# Altium schematic sheet Max +2A Issue 1a.SchDoc
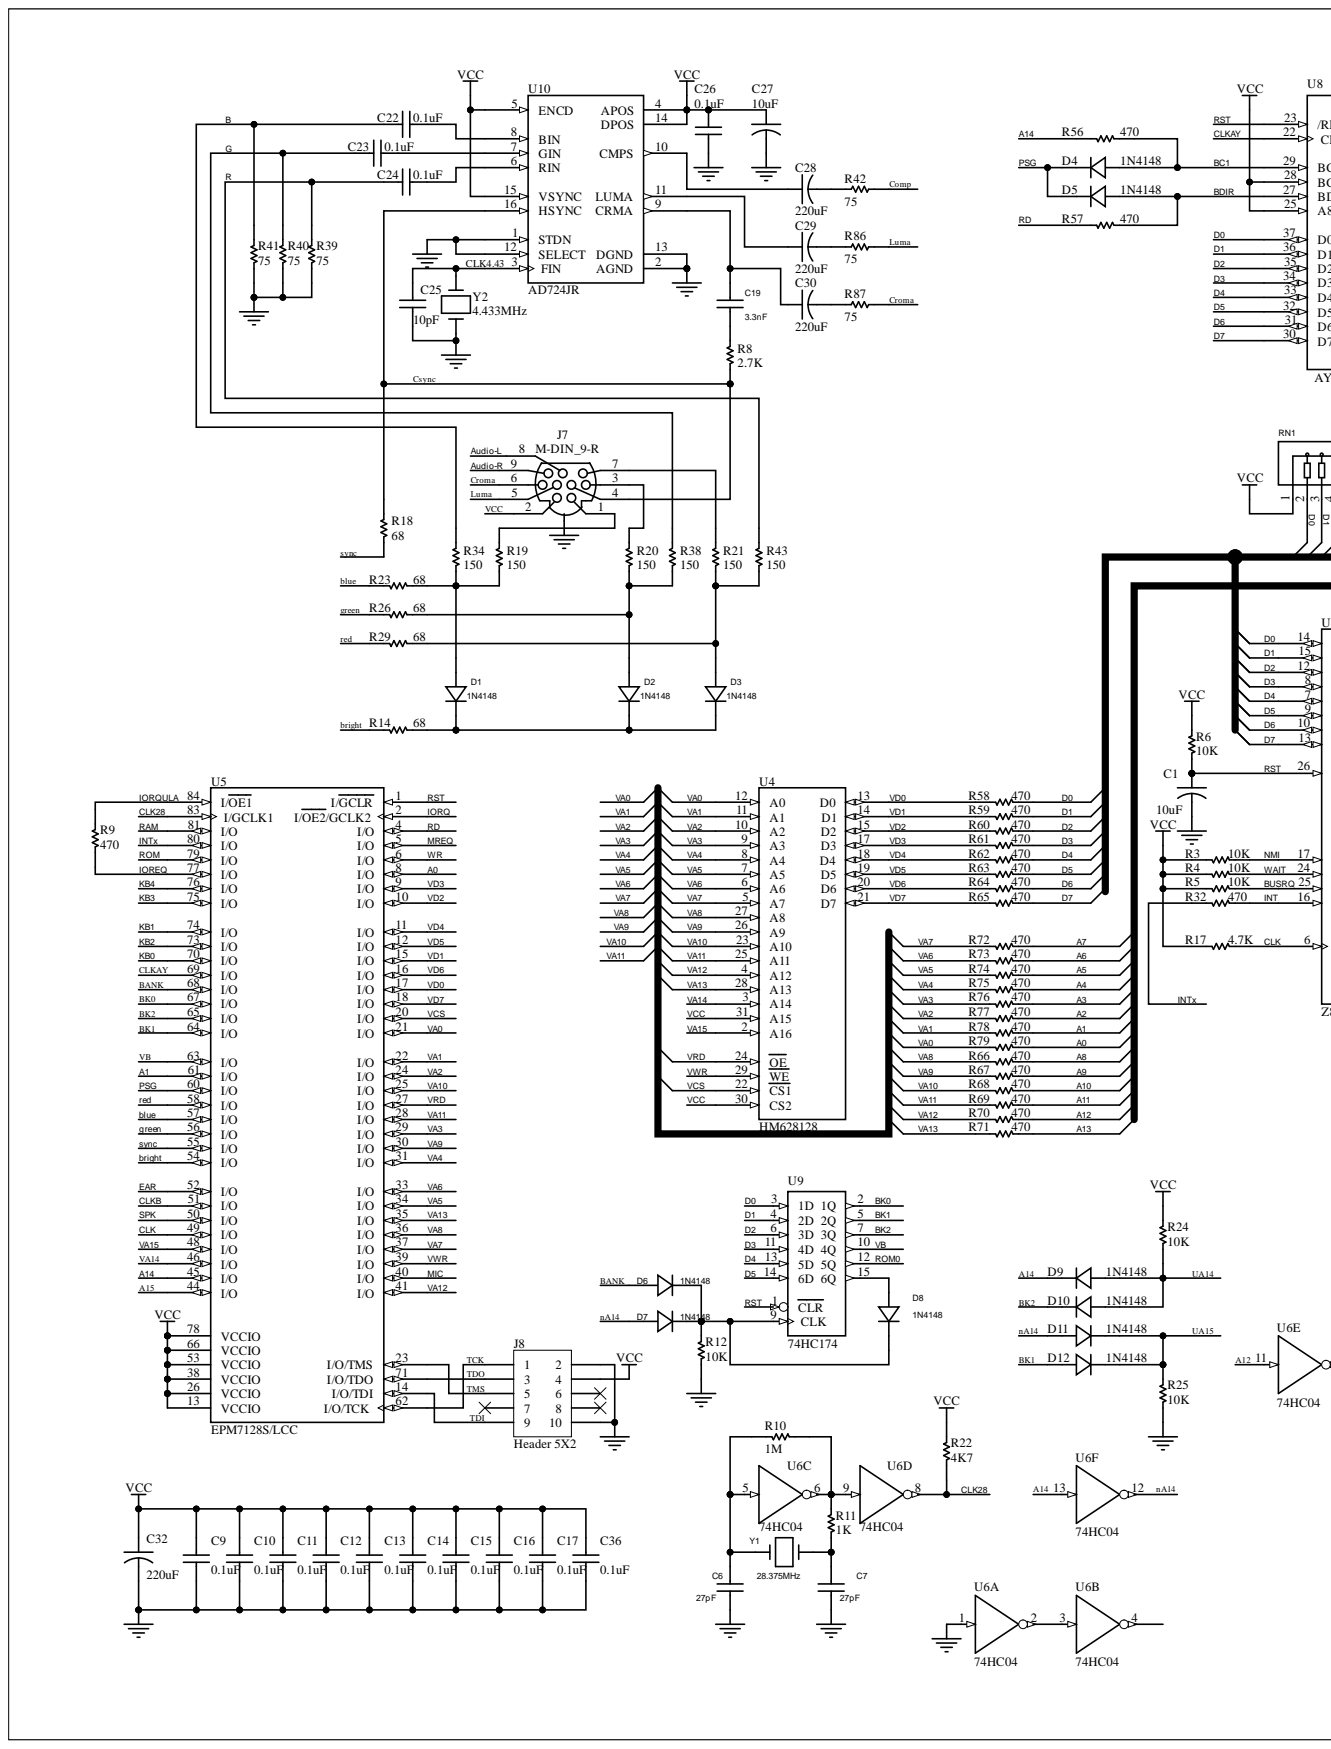
[diagram p0: Max +2A Issue 1a.SchDoc, left]
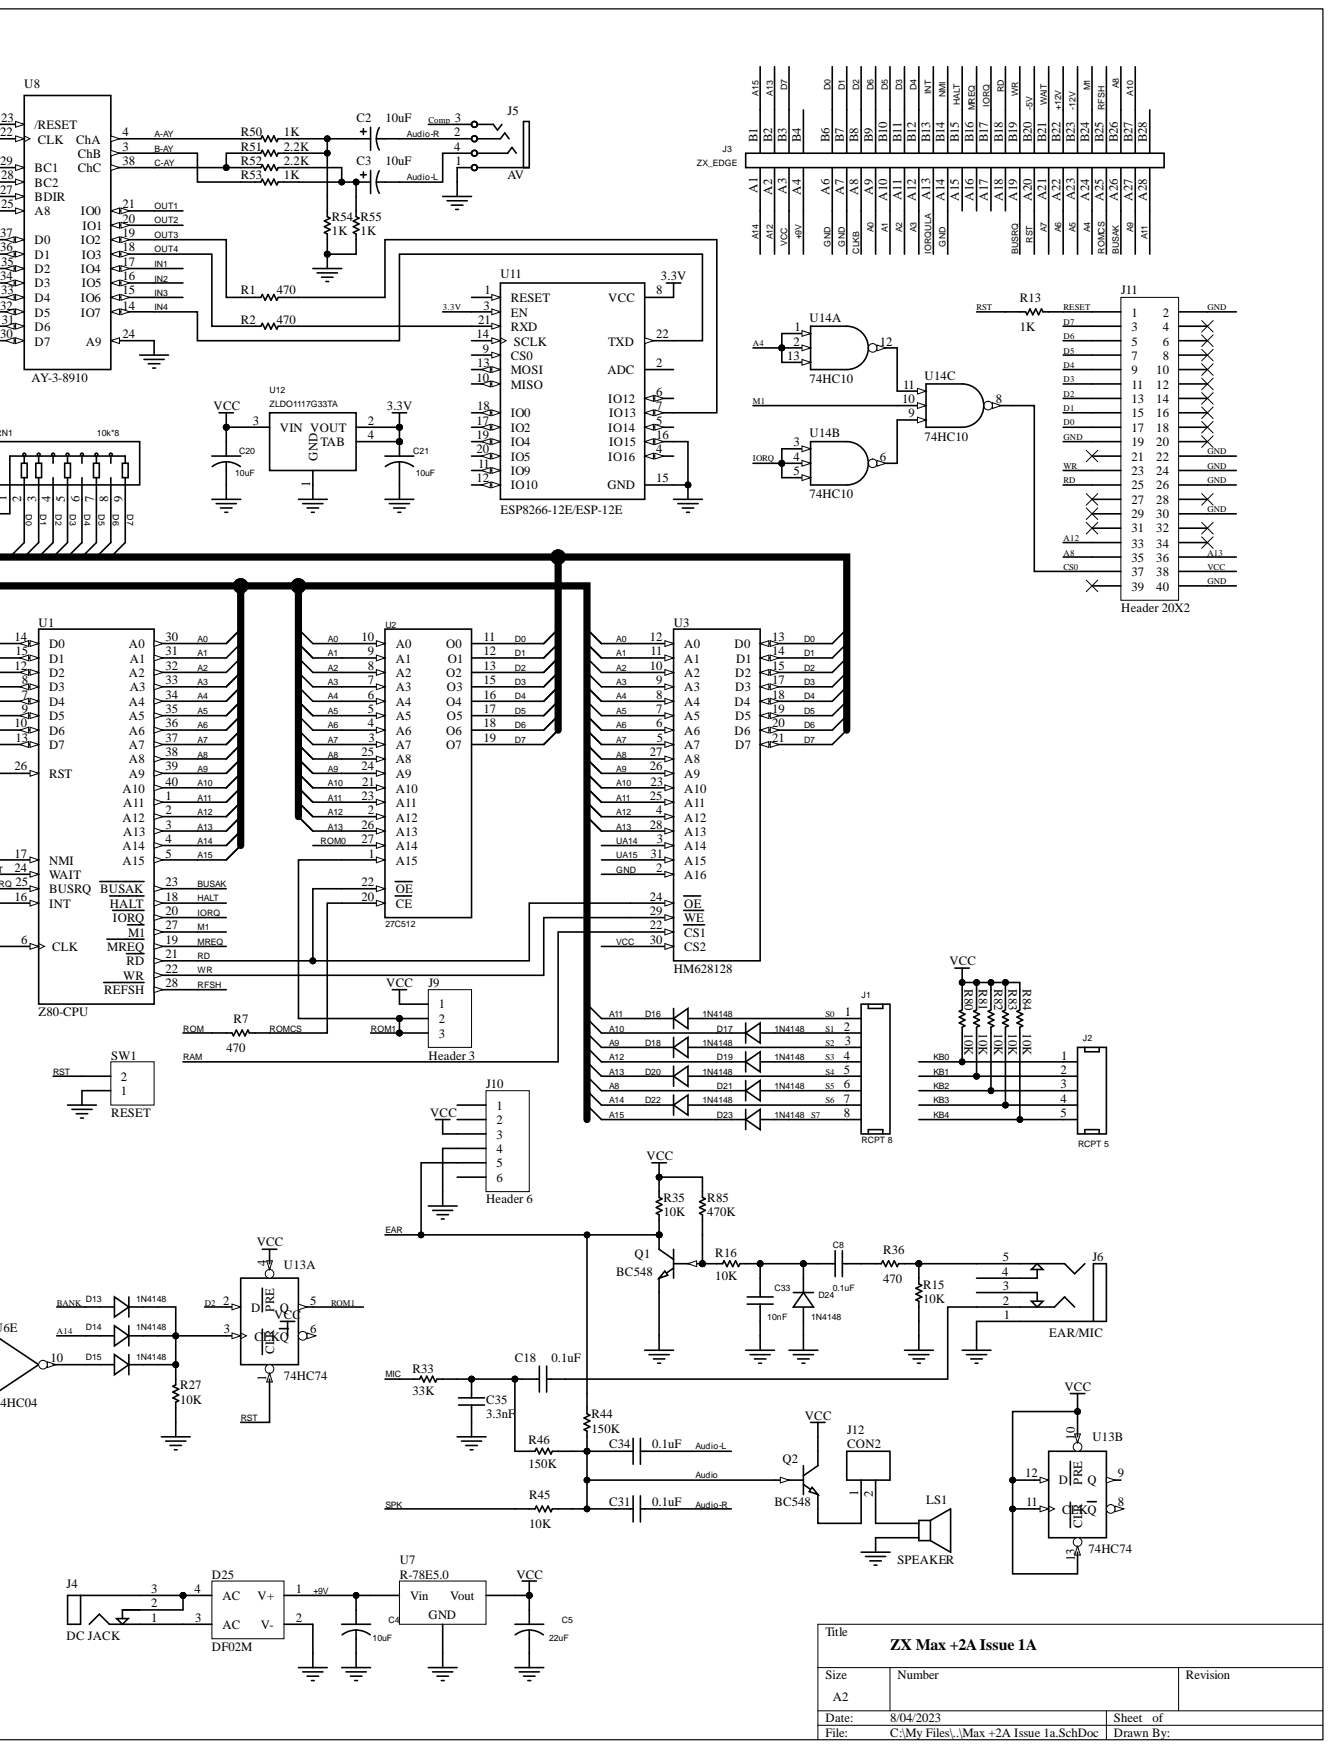
[diagram p1: Max +2A Issue 1a.SchDoc, right]

## Parts
C1  10uF  [CYL/D.150/LS.100/.031]
C2  10uF  [CYL/D.150/LS.100/.031]
C3  10uF  [CYL/D.150/LS.100/.031]
C4  10uF  [CYL/D.150/LS.100/.031]
C5  22uF  [CYL/D.150/LS.100/.031]
C6  27pF  [RAD/.200X.100/LS.100/.031]
C7  27pF  [RAD/.200X.100/LS.100/.031]
C8  0.1uF  [RAD/.200X.100/LS.100/.031]
C9  0.1uF  [RAD/.200X.100/LS.100/.031]
C10  0.1uF  [RAD/.200X.100/LS.100/.031]
C11  0.1uF  [RAD/.200X.100/LS.100/.031]
C12  0.1uF  [RAD/.200X.100/LS.100/.031]
C13  0.1uF  [RAD/.200X.100/LS.100/.031]
C14  0.1uF  [RAD/.200X.100/LS.100/.031]
C15  0.1uF  [RAD/.250X.100/LS.150/.031]
C16  0.1uF  [RAD/.200X.100/LS.100/.031]
C17  0.1uF  [RAD/.200X.100/LS.100/.031]
C18  0.1uF  [RAD/.200X.100/LS.100/.031]
C19  3.3nF  [RAD/.200X.100/LS.100/.031]
C20  10uF  [CYL/D.150/LS.100/.031]
C21  10uF  [CYL/D.150/LS.100/.031]
C22  0.1uF  [RAD/.200X.100/LS.100/.031]
C23  0.1uF  [RAD/.200X.100/LS.100/.031]
C24  0.1uF  [RAD/.200X.100/LS.100/.031]
C25  10pF  [RAD/.200X.100/LS.100/.031]
C26  0.1uF  [RAD/.200X.100/LS.100/.031]
C27  10uF  [CYL/D.150/LS.100/.031]
C28  220uF  [CYL/D.200/LS.100/.031]
C29  220uF  [CYL/D.200/LS.100/.031]
C30  220uF  [CYL/D.200/LS.100/.031]
C31  0.1uF  [RAD/.200X.100/LS.100/.031]
C32  220uF  [CYL/D.200/LS.100/.031]
C33  10nF  [RAD/.200X.100/LS.100/.031]
C34  0.1uF  [RAD/.200X.100/LS.100/.031]
C35  3.3nF  [RAD/.200X.100/LS.100/.031]
C36  0.1uF  [RAD/.200X.100/LS.100/.031]
D1  1N4148  [DAX2/.300X.050/.028]
D2  1N4148  [DAX2/.300X.050/.028]
D3  1N4148  [DAX2/.300X.050/.028]
D4  1N4148  [DAX2/.300X.050/.028]
D5  1N4148  [DAX2/.300X.050/.028]
D6  1N4148  [DAX2/.300X.050/.028]
D7  1N4148  [DAX2/.300X.050/.028]
D8  1N4148  [DAX2/.300X.050/.028]
D9  1N4148  [DAX2/.300X.050/.028]
D10  1N4148  [DAX2/.300X.050/.028]
D11  1N4148  [DAX2/.300X.050/.028]
D12  1N4148  [DAX2/.300X.050/.028]
D13  1N4148  [DAX2/.300X.050/.028]
D14  1N4148  [DAX2/.300X.050/.028]
D15  1N4148  [DAX2/.300X.050/.028]
D16  1N4148  [DAX2/.300X.050/.028]
D17  1N4148  [DAX2/.300X.050/.028]
D18  1N4148  [DAX2/.300X.050/.028]
D19  1N4148  [DAX2/.300X.050/.028]
D20  1N4148  [DAX2/.300X.050/.028]
D21  1N4148  [DAX2/.300X.050/.028]
D22  1N4148  [DAX2/.300X.050/.028]
D23  1N4148  [DAX2/.300X.050/.028]
D24  1N4148  [DAX2/.300X.050/.028]
D25  DF02M  [DIP.100/4/W.300/L.300]
J1  RCPT 8  [BLKCON.100/VH/TM1SQS/W.100/8]
J2  RCPT 5  [BLKCON.100/VH/TM1SQS/W.100/5]
J3  ZX_EDGE  [ZX_EDGE]  (no BOM)
J4  DC JACK  [DC JACK SLOT]
J5  AV  [AUDIO JACK PJ-322]
J6  EAR/MIC  [AUDIO JACK PSG01538]
J7  M-DIN_9-R  [DINC/MIN_TM/9]
J8  Header 5X2  [HDR2X5]
J9  Header 3  [HDR1X3]
J10  Header 6  [HDR1X6]
J11  Header 20X2  [HEADER/RP 2X20]
J12  CON2  [SIP/TM/L.200/2]
LS1  SPEAKER  [SPEAKER-23MM]
Q1  BC548  [TO92/321]
Q2  BC548  [TO92/321]
R1  470  [AX/.350X.100/.034]
R2  470  [AX/.350X.100/.034]
R3  10K  [AX/.350X.100/.034]
R4  10K  [AX/.350X.100/.034]
R5  10K  [AX/.350X.100/.034]
R6  10K  [AX/.350X.100/.034]
R7  470  [AX/.350X.100/.034]
R8  2.7K  [AX/.350X.100/.034]
R9  470  [AX/.350X.100/.034]
R10  1M  [AX/.350X.100/.034]
R11  1K  [AX/.350X.100/.034]
R12  10K  [AX/.350X.100/.034]
R13  1K  [AX/.350X.100/.034]
R14  68  [AX/.350X.100/.034]
R15  10K  [AX/.350X.100/.034]
R16  10K  [AX/.350X.100/.034]
R17  4.7K  [AX/.350X.100/.034]
R18  68  [AX/.350X.100/.034]
R19  150  [AX/.350X.100/.034]
R20  150  [AX/.350X.100/.034]
R21  150  [AX/.350X.100/.034]
R22  4K7  [AX/.350X.100/.034]
R23  68  [AX/.350X.100/.034]
R24  10K  [AX/.350X.100/.034]
R25  10K  [AX/.350X.100/.034]
R26  68  [AX/.350X.100/.034]
R27  10K  [AX/.350X.100/.034]
R29  68  [AX/.350X.100/.034]
R32  470  [AX/.350X.100/.034]
R33  33K  [AX/.350X.100/.034]
R34  150  [AX/.350X.100/.034]
R35  10K  [AX/.350X.100/.034]
R36  470  [AX/.350X.100/.034]
R38  150  [AX/.350X.100/.034]
R39  75  [AX/.350X.100/.034]
R40  75  [AX/.350X.100/.034]
R41  75  [AX/.350X.100/.034]
R42  75  [AX/.350X.100/.034]
R43  150  [AX/.350X.100/.034]
R44  150K  [AX/.350X.100/.034]
R45  10K  [AX/.350X.100/.034]
R46  150K  [AX/.350X.100/.034]
R50  1K  [AX/.350X.100/.034]
R51  2.2K  [AX/.350X.100/.034]
R52  2.2K  [AX/.350X.100/.034]
R53  1K  [AX/.350X.100/.034]
R54  1K  [AX/.350X.100/.034]
R55  1K  [AX/.350X.100/.034]
R56  470  [AX/.350X.100/.034]
R57  470  [AX/.350X.100/.034]
R58  470  [AX/.350X.100/.034]
R59  470  [AX/.350X.100/.034]
R60  470  [AX/.350X.100/.034]
R61  470  [AX/.350X.100/.034]
R62  470  [AX/.350X.100/.034]
R63  470  [AX/.350X.100/.034]
R64  470  [AX/.350X.100/.034]
R65  470  [AX/.350X.100/.034]
R66  470  [AX/.350X.100/.034]
R67  470  [AX/.350X.100/.034]
R68  470  [AX/.350X.100/.034]
R69  470  [AX/.350X.100/.034]
R70  470  [AX/.350X.100/.034]
R71  470  [AX/.350X.100/.034]
R72  470  [AX/.350X.100/.034]
R73  470  [AX/.350X.100/.034]
R74  470  [AX/.350X.100/.034]
R75  470  [AX/.350X.100/.034]
R76  470  [AX/.350X.100/.034]
R77  470  [AX/.350X.100/.034]
R78  470  [AX/.350X.100/.034]
R79  470  [AX/.350X.100/.034]
R80  10K  [AX/.350X.100/.034]
R81  10K  [AX/.350X.100/.034]
R82  10K  [AX/.350X.100/.034]
R83  10K  [AX/.350X.100/.034]
R84  10K  [AX/.350X.100/.034]
R85  470K  [AX/.350X.100/.034]
R86  75  [AX/.350X.100/.034]
R87  75  [AX/.350X.100/.034]
RN1  10k*8  [SIP/TM/L.900/9]
SW1  RESET  [SW-PB-450-1658-ND]
U1  Z80-CPU  [DIP.100/40/W.600/L2.025]
U2  27C512  [DIP.100/28/W.600/L1.400]
U3  HM628128  [DIP.100/32/W.300-600/L1.600-2]
U4  HM628128  [DIP.100/32/W.600/L1.600]
U5  EPM7128S/LCC  [PLCC84/TMS/1.425]
U6  74HC04  [DIP.100/14/W.300/L.700]  (parts A,B,C,D,E,F)
U7  R-78E5.0  [R-78E5.0]
U8  AY-3-8910  [DIP.100/40/W.600/L2.025]
U9  74HC174  [DIP.100/16/W.300/L.775]
U10  AD724JR  [SOJ.050/16/WB.400/L.400]
U11  ESP8266-12E/ESP-12E  [ESP8266-12E]
U12  ZLDO1117G33TA  [SM/SOT223]
U13  74HC74  [DIP.100/14/W.300/L.700]  (parts A,B)
U14  74HC10  [DIP.100/14/W.300/L.700]  (parts A,B,C)
Y1  28.375MHz  [RAD/.300X.125/LS.200/.031]
Y2  4.433MHz  [RAD/.300X.125/LS.200/.031]

## Nets
3.3V: C21.1 U11.3(EN) U11.8(VCC) U12.2(VOUT) U12.4(TAB)
+9V: C4.1 D25.1(V+) J3.A4 U7.1
+12V: J3.B22(A22)
-5V: J3.B20(A20)
-12V: J3.B23
A0: J3.A9 R79.1 U1.30(A0) U2.10(A0) U3.12(A0) U5.8(I/O)
A1: J3.A10 R78.1 U1.31(A1) U2.9(A1) U3.11(A1) U5.61(I/O)
A2: J3.A11 R77.1 U1.32(A2) U2.8(A2) U3.10(A2)
A3: J3.A12 R76.1 U1.33(A3) U2.7(A3) U3.9(A3)
A4: J3.A24 R75.1 U1.34(A4) U2.6(A4) U3.8(A4) U14.1 U14.2 U14.13
A5: J3.A23 R74.1 U1.35(A5) U2.5(A5) U3.7(A5)
A6: J3.A22 R73.1 U1.36(A6) U2.4(A6) U3.6(A6)
A7: J3.A21 R72.1 U1.37(A7) U2.3(A7) U3.5(A7)
A8: D21.2 J3.B26 J11.35 R66.1 U1.38(A8) U2.25(A8) U3.27(A8)
A9: D18.2 J3.A27 R67.1 U1.39(A9) U2.24(A9) U3.26(A9)
A10: D17.2 J3.B27 R68.1 U1.40(A10) U2.21(A10) U3.23(A10)
A11: D16.2 J3.A28 R69.1 U1.1(A11) U2.23(A11) U3.25(A11)
A12: D19.2 J3.A2 J11.33 R70.1 U1.2(A12) U2.2(A12) U3.4(A12) U6.11
A13: D20.2 J3.B2(A2) J11.36 R71.1 U1.3(A13) U2.26(A13) U3.28(A13)
A14: D9.2 D14.1 D22.2 J3.A1 R56.2 U1.4(A14) U5.45(I/O) U6.13
A15: D23.2 J3.B1(A1) U1.5(A15) U5.44(I/O)
A-AY: R50.2 U8.4(ChA)
Audio: C31.1 C34.1 Q2.2 R44.2 R45.1 R46.1
Audio-L: C3.2 C34.2 J5.4 J7.8
Audio-R: C2.2 C31.2 J5.2 J7.9
B: C22.2 R34.1 R41.1
B-AY: R53.2 U8.3(ChB)
BANK: D6.1 D13.1 U5.68(I/O)
BC1: D4.1 R56.1 U8.29(BC1)
BDIR: D5.1 R57.1 U8.27(BDIR)
BK0: U5.67(I/O) U9.2(1Q)
BK1: D12.1 U5.64(I/O) U9.5(2Q)
BK2: D10.2 U5.65(I/O) U9.7(3Q)
blue: R23.2 U5.57(I/O)
bright: R14.2 U5.54(I/O)
BUSAK: J3.A26 U1.23(BUSAK)
BUSRQ: J3.A19 R5.1 U1.25(BUSRQ)
C-AY: R51.2 R52.2 U8.38(ChC)
CLK: R17.1 U1.6(CLK) U5.49(I/O)
CLK4.43: C25.1 U10.3(FIN) Y2.2
CLK28: R22.2 U5.83(I/GCLK1) U6.8
CLKAY: U5.69(I/O) U8.22(CLK)
CLKB: J3.A8 U5.51(I/O)
Comp: J5.3 R42.2
Croma: J7.6 R87.2
CS0: J11.37 U14.8
Csync: J7.4 R8.2 R18.1 U10.16(HSYNC)
D0: J3.B6(A6) J11.17 R58.1 RN1.2 U1.14(D0) U2.11(O0) U3.13(D0) U8.37(D0) U9.3(1D)
D1: J3.B7(A7) J11.15 R59.1 RN1.3 U1.15(D1) U2.12(O1) U3.14(D1) U8.36(D1) U9.4(2D)
D2: J3.B8 J11.13 R60.1 RN1.4 U1.12(D2) U2.13(O2) U3.15(D2) U8.35(D2) U9.6(3D) U13.2(D)
D3: J3.B11 J11.11 R61.1 RN1.5 U1.8(D3) U2.15(O3) U3.17(D3) U8.34(D3) U9.11(4D)
D4: J3.B12 J11.9 R62.1 RN1.6 U1.7(D4) U2.16(O4) U3.18(D4) U8.33(D4) U9.13(5D)
D5: J3.B10 J11.7 R63.1 RN1.7 U1.9(D5) U2.17(O5) U3.19(D5) U8.32(D5) U9.14(6D)
D6: J3.B9 J11.5 R64.1 RN1.8 U1.10(D6) U2.18(O6) U3.20(D6) U8.31(D6)
D7: J3.B3(A3) J11.3 R65.1 RN1.9 U1.13(D7) U2.19(O7) U3.21(D7) U8.30(D7)
EAR: J10.5 Q1.3 R35.1 R44.1 U5.52(I/O)
G: C23.2 R38.1 R40.1
GND: C1.2 C4.2 C5.2 C6.2 C7.2 C9.2 C10.2 C11.2 C12.2 C13.2 C14.2 C15.2 C16.2 C17.2 C20.2 C21.2 C25.2 C26.2 C27.2 C32.2 C33.1 C35.1 C36.2 D24.1 D25.2(V-) J3.A6 J3.A7 J3.A14 J5.1 J6.1 J7.CASE J8.2 J8.10 J10.4 J11.2 J11.19 J11.22 J11.24 J11.26 J11.30 J11.40 LS1.2 Q1.1 R12.2 R15.1 R25.1 R27.2 R39.2 R40.2 R41.2 R54.1 R55.1 SW1.1 U1.29 U2.14 U3.2(A16) U3.16 U4.16 U5.7 U5.19 U5.32 U5.42 U5.47 U5.59 U5.72 U5.82 U6.1 U6.7 U7.2 U8.1 U8.24(A9) U9.8 U10.1(STDN) U10.2(AGND) U10.12(SELECT) U10.13(DGND) U11.15(GND) U11.16(IO15) U12.1(GND) U13.7 U14.7 Y2.1
green: R26.2 U5.56(I/O)
HALT: J3.B15(A15) U1.18(HALT)
IN1: U8.17(IO4)
IN2: U8.16(IO5)
IN3: U8.15(IO6)
IN4: U8.14(IO7) U11.22(TXD)
INT: J3.B13 R32.1 U1.16(INT)
INTx: R32.2 U5.80(I/O)
IOREQ: R9.2 U5.77(I/O)
IORQ: J3.B17(A17) U1.20(IORQ) U5.2(I/OE2/GCLK2) U14.3 U14.4 U14.5
IORQULA: J3.A13 R9.1 U5.84(I/OE1)
KB0: J2.1 R80.1 U5.70(I/O)
KB1: J2.2 R81.1 U5.74(I/O)
KB2: J2.3 R82.1 U5.73(I/O)
KB3: J2.4 R83.1 U5.75(I/O)
KB4: J2.5 R84.1 U5.76(I/O)
Luma: J7.5 R86.2
M1: J3.B24 U1.27(M1) U14.10
MIC: R33.2 U5.40(I/O)
MREQ: J3.B16 U1.19(MREQ) U5.5(I/O)
nA14: D7.1 D11.1 U6.12
NMI: J3.B14 R3.1 U1.17(NMI)
OUT1: U8.21(IO0)
OUT2: U8.20(IO1)
OUT3: R1.1 U8.19(IO2)
OUT4: R2.1 U8.18(IO3)
PSG: D4.2 D5.2 U5.60(I/O)
R: C24.2 R39.1 R43.1
RAM: U3.22(CS1) U5.81(I/O)
RD: J3.B18(A18) J11.25 R57.2 U1.21(RD) U2.22(OE) U3.24(OE) U5.4(I/O)
red: R29.2 U5.58(I/O)
RESET: J11.1 R13.1
RFSH: J3.B25 U1.28(REFSH)
ROM: R7.2 U5.79(I/O)
ROM0: U2.27(A14) U9.12(5Q)
ROM1: J9.2 J9.3 U2.1(A15) U13.5(Q)
ROMCS: J3.A25 R7.1 U2.20(CE)
RST: C1.1 J3.A20 R6.1 R13.2 SW1.2 U1.26(RST) U5.1(I/GCLR) U8.23(/RESET) U9.1(CLR) U13.1(CLR)
S0: D16.1 J1.1
S1: D17.1 J1.2
S2: D18.1 J1.3
S3: D19.1 J1.4
S4: D20.1 J1.5
S5: D21.1 J1.6
S6: D22.1 J1.7
S7: D23.1 J1.8
SPK: R45.2 U5.50(I/O)
sync: R18.2 U5.55(I/O)
TCK: J8.1 U5.62(I/O/TCK)
TDI: J8.9 U5.14(I/O/TDI)
TDO: J8.3 U5.71(I/O/TDO)
TMS: J8.5 U5.23(I/O/TMS)
UA14: D9.1 D10.1 R24.1 U3.3(A14)
UA15: D11.2 D12.2 R25.2 U3.31(A15)
VA0: R79.2 U4.12(A0) U5.21(I/O)
VA1: R78.2 U4.11(A1) U5.22(I/O)
VA2: R77.2 U4.10(A2) U5.24(I/O)
VA3: R76.2 U4.9(A3) U5.29(I/O)
VA4: R75.2 U4.8(A4) U5.31(I/O)
VA5: R74.2 U4.7(A5) U5.34(I/O)
VA6: R73.2 U4.6(A6) U5.33(I/O)
VA7: R72.2 U4.5(A7) U5.37(I/O)
VA8: R66.2 U4.27(A8) U5.36(I/O)
VA9: R67.2 U4.26(A9) U5.30(I/O)
VA10: R68.2 U4.23(A10) U5.25(I/O)
VA11: R69.2 U4.25(A11) U5.28(I/O)
VA12: R70.2 U4.4(A12) U5.41(I/O)
VA13: R71.2 U4.28(A13) U5.35(I/O)
VA14: U4.3(A14) U5.46(I/O)
VA15: U4.2(A16) U5.48(I/O)
VB: U5.63(I/O) U9.10(4Q)
VCC: C5.1 C9.1 C10.1 C11.1 C12.1 C13.1 C14.1 C15.1 C16.1 C17.1 C20.1 C26.1 C27.1 C32.1 C36.1 J3.A3 J7.2 J8.4 J9.1 J10.3 J11.38 Q2.3 R3.2 R4.2 R5.2 R6.2 R17.2 R22.1 R24.2 R35.2 R80.2 R81.2 R82.2 R83.2 R84.2 R85.2 RN1.1 U1.11 U2.28 U3.30(CS2) U3.32 U4.30(CS2) U4.31(A15) U4.32 U5.3 U5.13(VCCIO) U5.26(VCCIO) U5.38(VCCIO) U5.43 U5.53(VCCIO) U5.66(VCCIO) U5.78(VCCIO) U6.14 U7.3 U8.25(A8) U8.28(BC2) U8.40 U9.16 U10.4(APOS) U10.5(ENCD) U10.14(DPOS) U10.15(VSYNC) U12.3(VIN) U13.4(PRE) U13.10(PRE) U13.11(CLK) U13.12(D) U13.13(CLR) U13.14 U14.14
VCS: U4.22(CS1) U5.20(I/O)
VD0: R58.2 U4.13(D0) U5.17(I/O)
VD1: R59.2 U4.14(D1) U5.15(I/O)
VD2: R60.2 U4.15(D2) U5.10(I/O)
VD3: R61.2 U4.17(D3) U5.9(I/O)
VD4: R62.2 U4.18(D4) U5.11(I/O)
VD5: R63.2 U4.19(D5) U5.12(I/O)
VD6: R64.2 U4.20(D6) U5.16(I/O)
VD7: R65.2 U4.21(D7) U5.18(I/O)
VRD: U4.24(OE) U5.27(I/O)
VWR: U4.29(WE) U5.39(I/O)
WAIT: J3.B21(A21) R4.1 U1.24(WAIT)
WR: J3.B19(A19) J11.23 U1.22(WR) U3.29(WE) U5.6(I/O)
NetC2_1: C2.1 R50.1 R51.1 R54.2
NetC3_1: C3.1 R52.1 R53.1 R55.2
NetC6_1: C6.1 R10.2 U6.5 Y1.2
NetC7_1: C7.1 R11.2 Y1.1
NetC8_1: C8.1 C33.2 D24.2 R16.1
NetC8_2: C8.2 R36.1
NetC18_1: C18.1 C35.2 R33.1 R46.2
NetC18_2: C18.2 J6.2
NetC19_1: C19.1 C30.1 U10.9(CRMA)
NetC19_2: C19.2 R8.1
NetC22_1: C22.1 U10.8(BIN)
NetC23_1: C23.1 U10.7(GIN)
NetC24_1: C24.1 U10.6(RIN)
NetC28_1: C28.1 U10.10(CMPS)
NetC28_2: C28.2 R42.1
NetC29_1: C29.1 U10.11(LUMA)
NetC29_2: C29.2 R86.1
NetC30_2: C30.2 R87.1
NetD1_1: D1.1 R19.2 R23.1 R34.2
NetD1_2: D1.2 D2.2 D3.2 R14.1
NetD2_1: D2.1 R20.2 R26.1 R38.2
NetD3_1: D3.1 R21.2 R29.1 R43.2
NetD6_2: D6.2 D7.2 D8.2 R12.1 U9.9(CLK)
NetD8_1: D8.1 U9.15(6Q)
NetD13_2: D13.2 D14.2 D15.2 R27.1 U13.3(CLK)
NetD15_1: D15.1 U6.10
NetD25_3: D25.3(AC) J4.1
NetD25_4: D25.4(AC) J4.2 J4.3
NetJ6_5: J6.5 R15.2 R36.2
NetJ7_1: J7.1 R19.1
NetJ7_3: J7.3 R20.1
NetJ7_7: J7.7 R21.1
NetJ12_1: J12.1 Q2.1
NetJ12_2: J12.2 LS1.1
NetQ1_2: Q1.2 R16.2 R85.1
NetR1_2: R1.2 U11.7(IO13)
NetR2_2: R2.2 U11.21(RXD)
NetR10_1: R10.1 R11.1 U6.6 U6.9
NetU6_2: U6.2 U6.3
NetU14_6: U14.6 U14.9
NetU14_11: U14.11 U14.12
N00000:

Not connected: J3 A15 A16 A17 A18 B4(A4) B28; J6 3 4; J8 6 7 8; J10 1 2 6; J11 4 6 8 10 12 14 16 18 20 21 27 28 29 31 32 34 39; U6 4; U11 1(RESET) 2(ADC) 4(IO16) 5(IO14) 6(IO12) 9(CS0) 10(MISO) 11(IO9) 12(IO10) 13(MOSI) 14(SCLK) 17(IO2) 18(IO0) 19(IO4) 20(IO5); U13 6(Q) 8(Q) 9(Q)

## Library data of the parts
C1 C27-C30 C32 = C: Component Kind: Standard; Library Name: HARLEQUIN REV G.SCHLIB
C2 C3 = Cap Pol1: Polarized Capacitor (Radial); Component Kind: Standard; LatestRevisionDate: 17-Jul-2002; LatestRevisionNote: Re-released for DXP Platform.; Library Name: Miscellaneous Devices.IntLib; PackageReference: RB7.6-15; Published: 8-Jun-2000; Signal Integrity: Cap Pol; Simulation: CAP; Value: 10uF; Publisher: Altium Limited
C4 C5 C20 C21 = C: COMPFIXED: NO; COMPGROUP: 0; COMPHEIGHT: 0.000; COMPLOC: [6125.000,2625.000]; COMPSIDE: TOP; COMPKEY: NO; COMPLOCKED: NO; Component Kind: Standard; COMPROT: 0; DECOUPLER: NO; MEMORY: NO
  C4 C5: Library Name: ZX Nuvo 48 Issue 2.SchLib
  C20 C21: Library Name: ZX Nuvo 128 Issue 3.SchLib
C6 C7 = CAP NP: COMPFIXED: NO; COMPGROUP: 0; COMPHEIGHT: 0.000; COMPLOC: [6275.000,975.000]; COMPSIDE: TOP; FPLIST: RAD/.150X.100/LS.100/.031; COMPKEY: NO; COMPLOCKED: NO; Component Kind: Standard; COMPROT: 0; DECOUPLER: NO; MEMORY: NO
C8 = CAP NP: COMPFIXED: NO; COMPGROUP: 0; COMPHEIGHT: 0.000; COMPLOC: [1875.000,4350.000]; COMPSIDE: TOP; COMPKEY: NO; COMPLOCKED: NO; Component Kind: Standard; COMPROT: 0; DECOUPLER: NO; MEMORY: NO
C9-C18 C22-C26 C31 C34-C36 = CAP NP: Component Kind: Standard; Library Name: HARLEQUIN REV G.SCHLIB
C19 = CAP NP: COMPFIXED: NO; COMPGROUP: 0; COMPHEIGHT: 0.000; COMPLOC: [350.000,1925.000]; COMPROT: 180; COMPSIDE: TOP; COMPKEY: NO; COMPLOCKED: NO; Component Kind: Standard; DECOUPLER: NO; MEMORY: NO
C33 = CAP NP: COMPFIXED: NO; COMPGROUP: 0; COMPHEIGHT: 0.000; COMPLOC: [2075.000,4350.000]; COMPSIDE: TOP; COMPKEY: NO; COMPLOCKED: NO; Component Kind: Standard; COMPROT: 0; DECOUPLER: NO; MEMORY: NO
D1-D3 D6-D8 D13-D24 = 1N4148: COMPFIXED: NO; COMPGROUP: 0; COMPHEIGHT: 0.000; COMPLOC: [7450.000,2300.000]; COMPROT: 90; COMPSIDE: TOP; COMPKEY: NO; COMPLOCKED: NO; Component Kind: Standard; DECOUPLER: NO; FPLIST: DAX2/.300X.050/.028; MEMORY: NO
D25 = Bridge: Diode Bridge; Component Kind: Standard; LatestRevisionDate: 17-Jul-2002; LatestRevisionNote: Re-released for DXP Platform.; PackageReference: D-46_6A; Published: 24-Mar-1999; Simulation: BRIDGE; Library Name: Retro Computer.SchLib; Publisher: Altium Limited
J1 = CONN RCPT 8_0: COMPFIXED: NO; COMPGROUP: 0; COMPHEIGHT: 0.000; COMPLOC: [6575.000,2175.000]; COMPSIDE: TOP; COMPKEY: NO; COMPLOCKED: NO; Component Kind: Standard; COMPROT: 0; DECOUPLER: NO; MEMORY: NO
J2 = CONN RCPT 5: COMPFIXED: NO; COMPGROUP: 0; COMPHEIGHT: 0.000; COMPLOC: [1750.000,3850.000]; COMPROT: 180; COMPSIDE: TOP; COMPKEY: NO; COMPLOCKED: NO; Component Kind: Standard; DECOUPLER: NO; MEMORY: NO; Library Name: Max 128 Issue 3.SchLib
J3 = ZX_EDGE_0: Library Name: HARLEQUIN REV G.SCHLIB
J4 = CONN JACK PWR: Component Kind: Standard; Library Name: HARLEQUIN REV G.SCHLIB
J5 = Phonejack4-PJ-322: Jack Socket, 3.5mm, Thru-Hole, Right Angle, 4-Conductor; DrillAndSlotSize: Corresponds to nominal value.; PackageDocument: 2003; PCB_Layout: Complies with manufacturer's recommendation.; Component Kind: Standard; ComponentLink1Description: Manufacturer Link; ComponentLink1URL: http://www.cliffuk.co.uk/; DatasheetDocument: 2003; PackageReference: S1VS_SBS; Published: 15-Jul-2004; Signal Integrity: JACK Socket; Library Name: Retro Computer.SchLib; Publisher: Altium Limited
J6 = PHONEJACK STEREO SW_0: Component Kind: Standard; Library Name: Retro Computer.SchLib
J8 = Header 5X2: Header, 5-Pin, Dual row; Component Kind: Standard; LatestRevisionDate: 17-Jul-2002; LatestRevisionNote: Re-released for DXP Platform.; Library Name: Miscellaneous Connectors.IntLib; Signal Integrity: Connector; Publisher: Altium Limited
J9 = Header 3: Header, 3-Pin; Component Kind: Standard; LatestRevisionDate: 17-Jul-2002; LatestRevisionNote: Re-released for DXP Platform.; Library Name: Miscellaneous Connectors.IntLib; Signal Integrity: Connector; Publisher: Altium Limited
J10 = Header 6: Header, 6-Pin; Component Kind: Standard; LatestRevisionDate: 17-Jul-2002; LatestRevisionNote: Re-released for DXP Platform.; Library Name: Miscellaneous Connectors.IntLib; Signal Integrity: Connector; Publisher: Altium Limited
J11 = Header 20X2: Header, 20-Pin, Dual row; Component Kind: Standard; LatestRevisionDate: 17-Jul-2002; LatestRevisionNote: Re-released for DXP Platform.; Library Name: Miscellaneous Connectors.IntLib; Signal Integrity: Connector; Publisher: Altium Limited
Q2 = 2N2222: Component Kind: Standard; Library Name: HARLEQUIN REV G.SCHLIB
R1-R27 R29 R32-R36 R38-R46 R50-R87 = Res1: Resistor; Component Kind: Standard; LatestRevisionDate: 17-Jul-2002; LatestRevisionNote: Re-released for DXP Platform.; PackageReference: AXIAL-0.3; Published: 8-Jun-2000; Signal Integrity: Res; Simulation: RESISTOR; Publisher: Altium Limited
  R1 R2: Library Name: Miscellaneous Devices.IntLib; PackageDescription: Axial Device, Thru-Hole; 2 Leads; 0.3 in Pin Spacing; Value: 470
  R3-R6 R12 R24 R25 R27 R80-R84: Library Name: Miscellaneous Devices.IntLib; Value: 10K
  R7 R32 R56-R79: Library Name: Miscellaneous Devices.IntLib; Value: 470
  R8: Library Name: Miscellaneous Devices.IntLib; Value: 2.7K
  R9 R36: Value: 470
  R10: Library Name: Miscellaneous Devices.IntLib; Value: 1M
  R11 R13 R50 R53-R55: Library Name: Miscellaneous Devices.IntLib; Value: 1K
  R14 R18 R23 R26 R29: Library Name: Miscellaneous Devices.IntLib; Value: 68
  R15 R16 R35 R45: Value: 10K
  R17: Library Name: Miscellaneous Devices.IntLib; Value: 4.7K
  R19-R21 R34 R38 R43: Library Name: Miscellaneous Devices.IntLib; Value: 150
  R22: Value: 4K7
  R33: Value: 33K
  R39-R42 R86 R87: Library Name: Miscellaneous Devices.IntLib; Value: 75
  R44 R46: Value: 150K
  R51 R52: Library Name: Miscellaneous Devices.IntLib; Value: 2.2K
  R85: Value: 470K
  R9 R15 R16 R22 R33 R35 R36 R44-R46 R85: Library Name: HARLEQUIN 128 Issue 3.SchLib
RN1 = RESNW_BU_8/SIP: COMPFIXED: NO; COMPGROUP: 0; COMPHEIGHT: 0.000; COMPLOC: [4375.000,2125.000]; COMPSIDE: TOP; FPLIST: SIP/TM/L1.000/10; COMPKEY: NO; COMPLOCKED: NO; Component Kind: Standard; COMPROT: 0; DECOUPLER: NO; MEMORY: NO
SW1 = Header 2: Header, 2-Pin; Component Kind: Standard; LatestRevisionDate: 17-Jul-2002; LatestRevisionNote: Re-released for DXP Platform.; Library Name: Miscellaneous Connectors.IntLib; Signal Integrity: Connector; Publisher: Altium Limited
U1 = Z80-CPU_6: Component Kind: Standard; Library Name: HARLEQUIN REV G.SCHLIB
U7 = Volt Reg: Voltage Regulator; Component Kind: Standard; LatestRevisionDate: 29-May-2009; LatestRevisionNote: IPC-7351 Footprint Added.; Library Name: Miscellaneous Devices.IntLib; PackageDescription: 3-Pin Transistor Outline Package 2.54 mm Pitch, 15.1 mm Lead Span; PackageReference: D2PAK; Published: 24-Mar-1999; Publisher: Altium Limited
U9 = 74HC174: IO_LEVEL: 0; PSpiceTemplate: X^@REFDES %C\L\R\ %CLK %1D %2D %3D %4D %5D %6D %1Q %2Q %3Q %4Q %5Q %6Q %VCC %GND @MODEL PARAMS:\n+ IO_LEVEL=@IO_LEVEL MNTYMXDLY=@MNTYMXDLY; Component Kind: Standard; MNTYMXDLY: 0; Simulation: 74HC174; Library Name: HARLEQUIN REV G128_Library.SchLib
U11 = ESP8266-12E: This WiFi module has a 32-bit MCU micro and clock speeds supporting 80 MHz or 160 MHz. Supports the RTOS and integrated Wi-Fi MAC/BB/RF/PA/LNA, and has an on-board antenna.; MF: AI-Thinker; Availability: Unavailable; Component Kind: Standard; MP: ESP8266-12E/ESP-12E; Package: None; Price: None; SnapEDA_Link: https://www.snapeda.com/parts/ESP8266-12E/ESP-12E/AI-Thinker/view-part/?ref=snap; Library Name: Retro Computer.SchLib
U12 = REGULATOR-1: COMPFIXED: NO; COMPGROUP: 0; COMPHEIGHT: 0.000; COMPLOC: [1665.000,1750.000]; COMPSIDE: BOT; COMPKEY: NO; COMPLOCKED: NO; Component Kind: Standard; COMPROT: 0; DECOUPLER: NO; Library Name: ZX_UNO_B.SchLib; MEMORY: NO
U13 = 74HC74: IO_LEVEL: 0; PSpiceTemplate: X^@REFDES %C\L\R\ %D %CLK %P\R\E\ %Q %Q\ %VCC %GND @MODEL PARAMS:\n+ IO_LEVEL=@IO_LEVEL MNTYMXDLY=@MNTYMXDLY; Component Kind: Standard; MNTYMXDLY: 0; Simulation: 74HC74; Library Name: Retro Computer.SchLib
U14 = 74HC10: Triple 3-Input Positive-NAND Gate; Code_IPC: SOIC127P600-14; Component Kind: Standard; ComponentLink1Description: Manufacturer Link; ComponentLink1URL: http://www.ti.com/; ComponentLink2Description: Datasheet; ComponentLink2URL: http://www-s.ti.com/sc/ds/sn74hc10.pdf; DatasheetVersion: May-1997; LatestRevisionDate: 13-Apr-2006; LatestRevisionNote: IPC-7351 Footprint Added.; PackageDescription: 14-Pin Small Outline Integrated Circuit 1.27 mm Pitch; PackageReference: D014; PackageVersion: Jan-1998; PCB3D: D014; Published: 8-Jun-2000; Signal Integrity: SN74HC10D; Library Name: HARLEQUIN 128 Issue 4.SchLib; Publisher: Altium Limited
Y1 = CRYSTAL: COMPFIXED: NO; COMPGROUP: 0; COMPHEIGHT: 0.000; COMPLOC: [6575.317,975.317]; COMPSIDE: TOP; COMPKEY: NO; COMPLOCKED: NO; Component Kind: Standard; COMPROT: 0; DECOUPLER: NO; MEMORY: NO
Y2 = CRYSTAL: Component Kind: Standard; Library Name: HARLEQUIN REV G.SCHLIB
D4 D5 D9-D12 = 1N4148: Component Kind: Standard; Library Name: HARLEQUIN REV G.SCHLIB
J7 = M-DIN_9-R: Component Kind: Standard; Library Name: Retro Computer.SchLib
J12 = CON2: Component Kind: Standard; Library Name: Max 128 Issue 3.SchLib
LS1 = SPEAKER: Component Kind: Standard; Library Name: HARLEQUIN REV G.SCHLIB
Q1 = BC548: Component Kind: Standard; Library Name: HARLEQUIN REV G128_Library.SchLib
U2 = 27C512: Component Kind: Standard; Library Name: Max +2A Issue 1.SchLib
U3 U4 = HM628128: Component Kind: Standard; Library Name: Retro Computer.SchLib
U5 = EPM7128S/LCC: Component Kind: Standard; Library Name: Retro Computer.SchLib
U6 = 74HC04: Component Kind: Standard; Simulation: 74HC04; Library Name: HARLEQUIN REV G.SchLib
U8 = AY-3-8910: Component Kind: Standard; Library Name: Retro Computer.SchLib
U10 = AD724JR: Component Kind: Standard; Library Name: HARLEQUIN REV G.SCHLIB
